# Altium schematic sheet Full-H Bridge_B.SchDoc("Full-H Bridge_B")
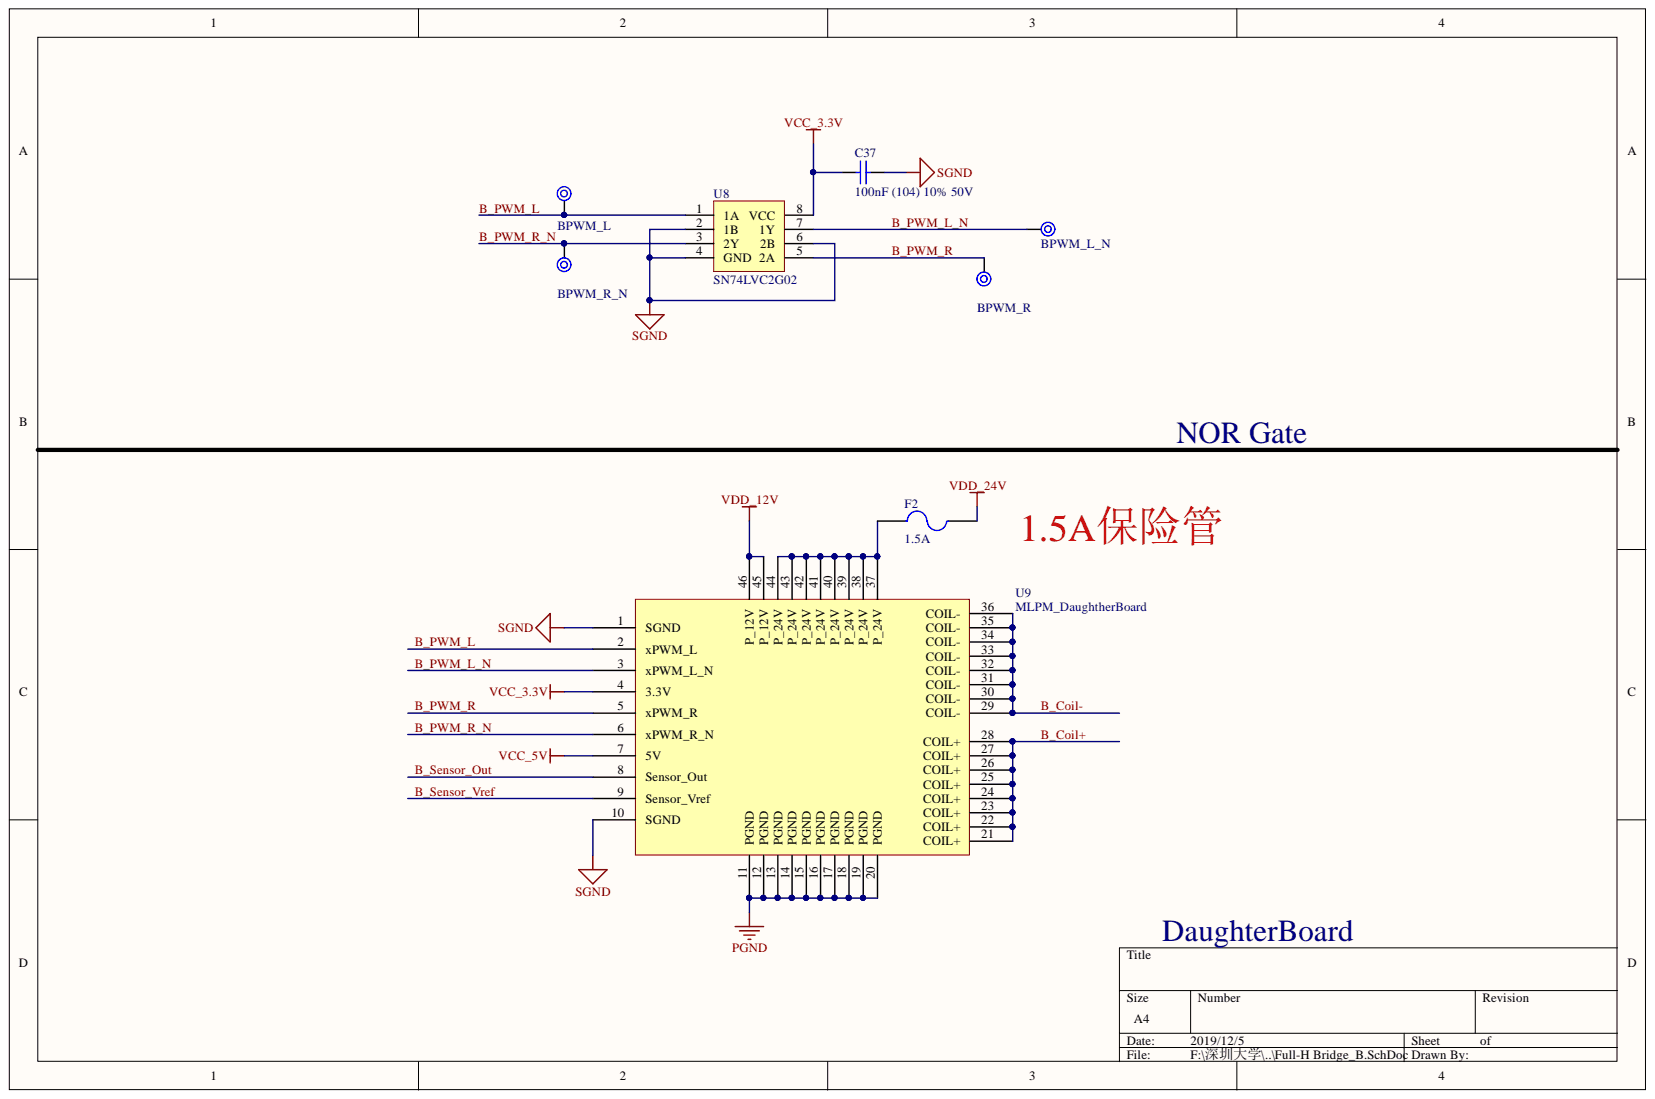
[diagram p0: Full-H Bridge_B.SchDoc]

## Parts
BPWM_L  TP_Surface  [TEST_POINT_ME]  (value not drawn)
BPWM_L_N  TP_Surface  [TEST_POINT_ME]  (value not drawn)
BPWM_R  TP_Surface  [TEST_POINT_ME]  (value not drawn)
BPWM_R_N  TP_Surface  [TEST_POINT_ME]  (value not drawn)
C37  100nF (104) 10% 50V  [0603_C]
F2  value 1.5A  [1812]  (hidden comment: Fuse)
U8  SN74LVC2G02  [SN74LVC2G02]
U9  MLPM_DaughtherBoard  [MLPM_DaughtherBoard]

## Nets
PGND: U9.11(PGND) U9.12(PGND) U9.13(PGND) U9.14(PGND) U9.15(PGND) U9.16(PGND) U9.17(PGND) U9.18(PGND) U9.19(PGND) U9.20(PGND)
SGND: C37.2 U8.2(1B) U8.4(GND) U8.6(2B) U9.1(SGND) U9.10(SGND)
VCC_3.3V: C37.1 U8.8(VCC) U9.4(3.3V)
VCC_5V: U9.7(5V)
VDD_12V: U9.45(P_12V) U9.46(P_12V)
VDD_24V: F2.2
B_Coil+: U9.21(COIL+) U9.22(COIL+) U9.23(COIL+) U9.24(COIL+) U9.25(COIL+) U9.26(COIL+) U9.27(COIL+) U9.28(COIL+)
B_Coil-: U9.29(COIL-) U9.30(COIL-) U9.31(COIL-) U9.32(COIL-) U9.33(COIL-) U9.34(COIL-) U9.35(COIL-) U9.36(COIL-)
B_PWM_L: BPWM_L.1 U8.1(1A) U9.2(xPWM_L)
B_PWM_L_N: BPWM_L_N.1 U8.7(1Y) U9.3(xPWM_L_N)
B_PWM_R: BPWM_R.1 U8.5(2A) U9.5(xPWM_R)
B_PWM_R_N: BPWM_R_N.1 U8.3(2Y) U9.6(xPWM_R_N)
B_Sensor_Out: U9.8(Sensor_Out)
B_Sensor_Vref: U9.9(Sensor_Vref)
NetF2_1: F2.1 U9.37(P_24V) U9.38(P_24V) U9.39(P_24V) U9.40(P_24V) U9.41(P_24V) U9.42(P_24V) U9.43(P_24V) U9.44(P_24V)

## Library data of the parts
C37 = 0603 100nF (104) 10% 50V: 贴片电容; SuppliersPartNumber: C14663; Supplier: LC; Package: 0603; Library Name: JLC Library.IntLib; ComponentLink1URL: http://www.szlcsc.com/product/details_15331.html; ComponentLink1Description: 供应商链接; Component Kind: Standard
F2 = Fuse 2: 保险丝; Published: 8-Jun-2000; PackageReference: PIN-W2/E2.8; LatestRevisionNote: Re-released for DXP Platform.; LatestRevisionDate: 17-Jul-2002; Component Kind: Standard; Publisher: Altium Limited; Library Name: 接插件集成库.IntLib
U8 = SN74LVC2G02: 2通道高速与非门; Component Kind: Standard; Library Name: PSRM_Sensorless_V2.0模块.IntLib
U9 = MLPM_Driver_DaugtherBoard: 磁悬浮平面电机电流驱动器子板; Component Kind: Standard; Library Name: MPLM_Driver_V10A1.IntLib
BPWM_L BPWM_L_N BPWM_R BPWM_R_N = TP_Surface: Component Kind: Standard; Library Name: PSRM_Sensorless_V2.0模块.IntLib
